# Altium schematic sheet Top_Level.SchDoc
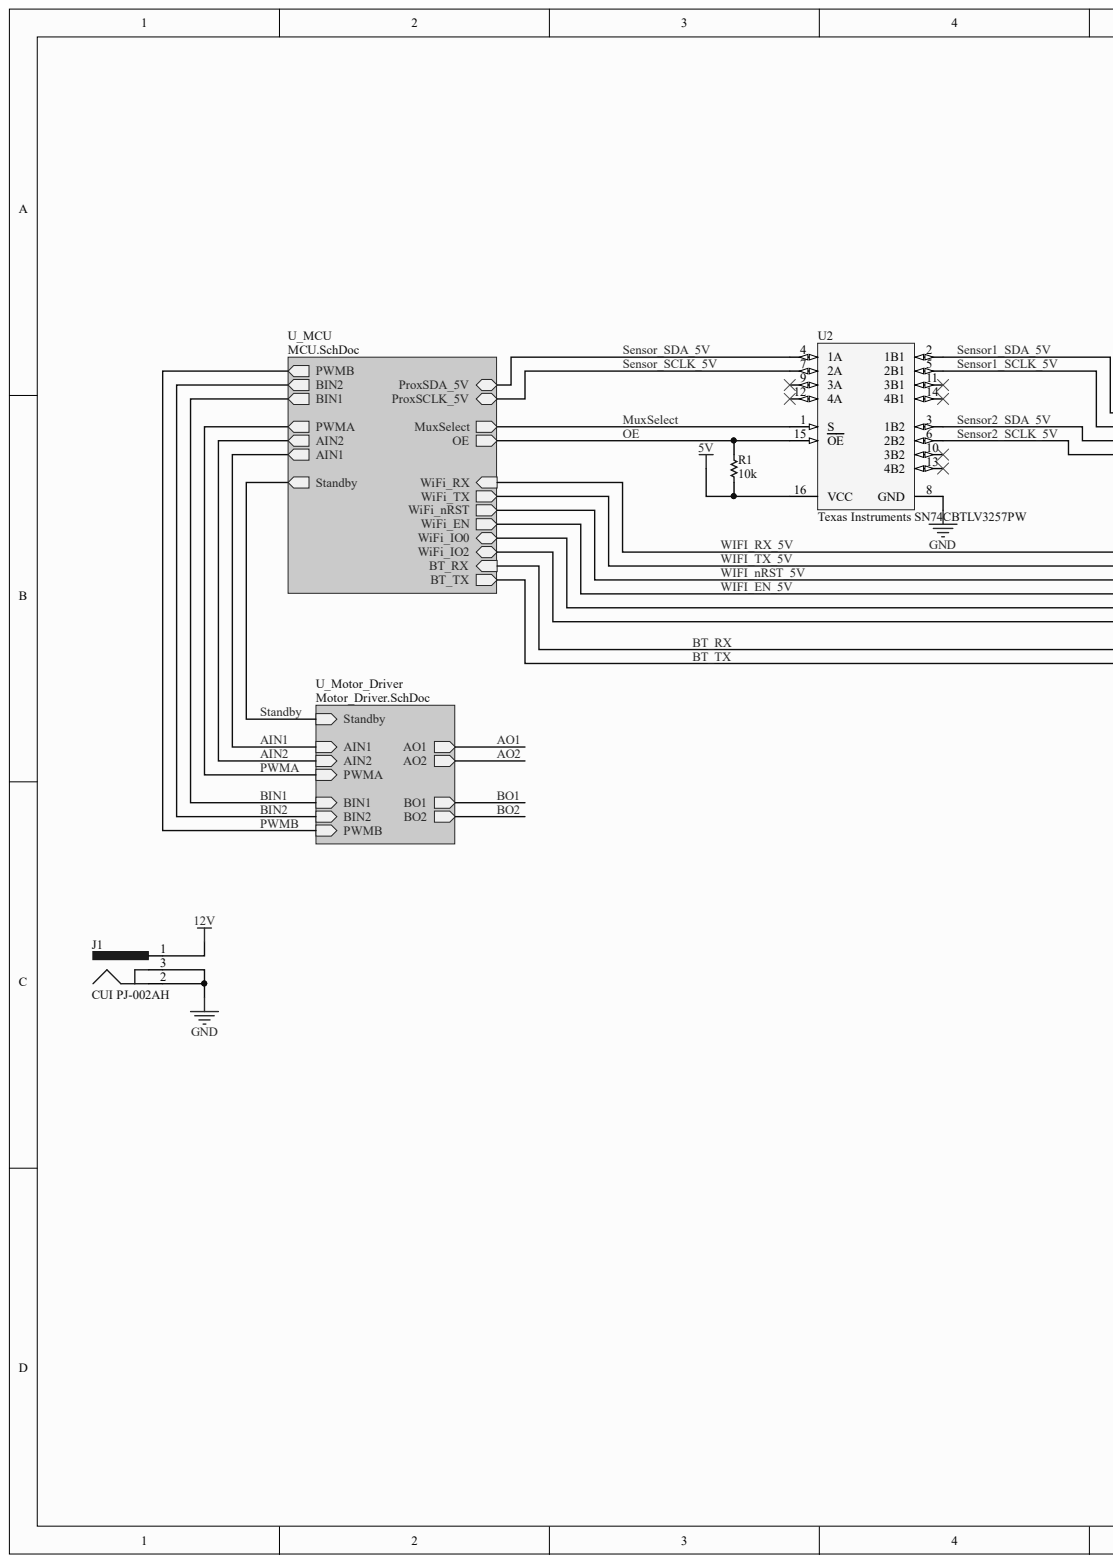
[diagram p0: Top_Level.SchDoc, left]
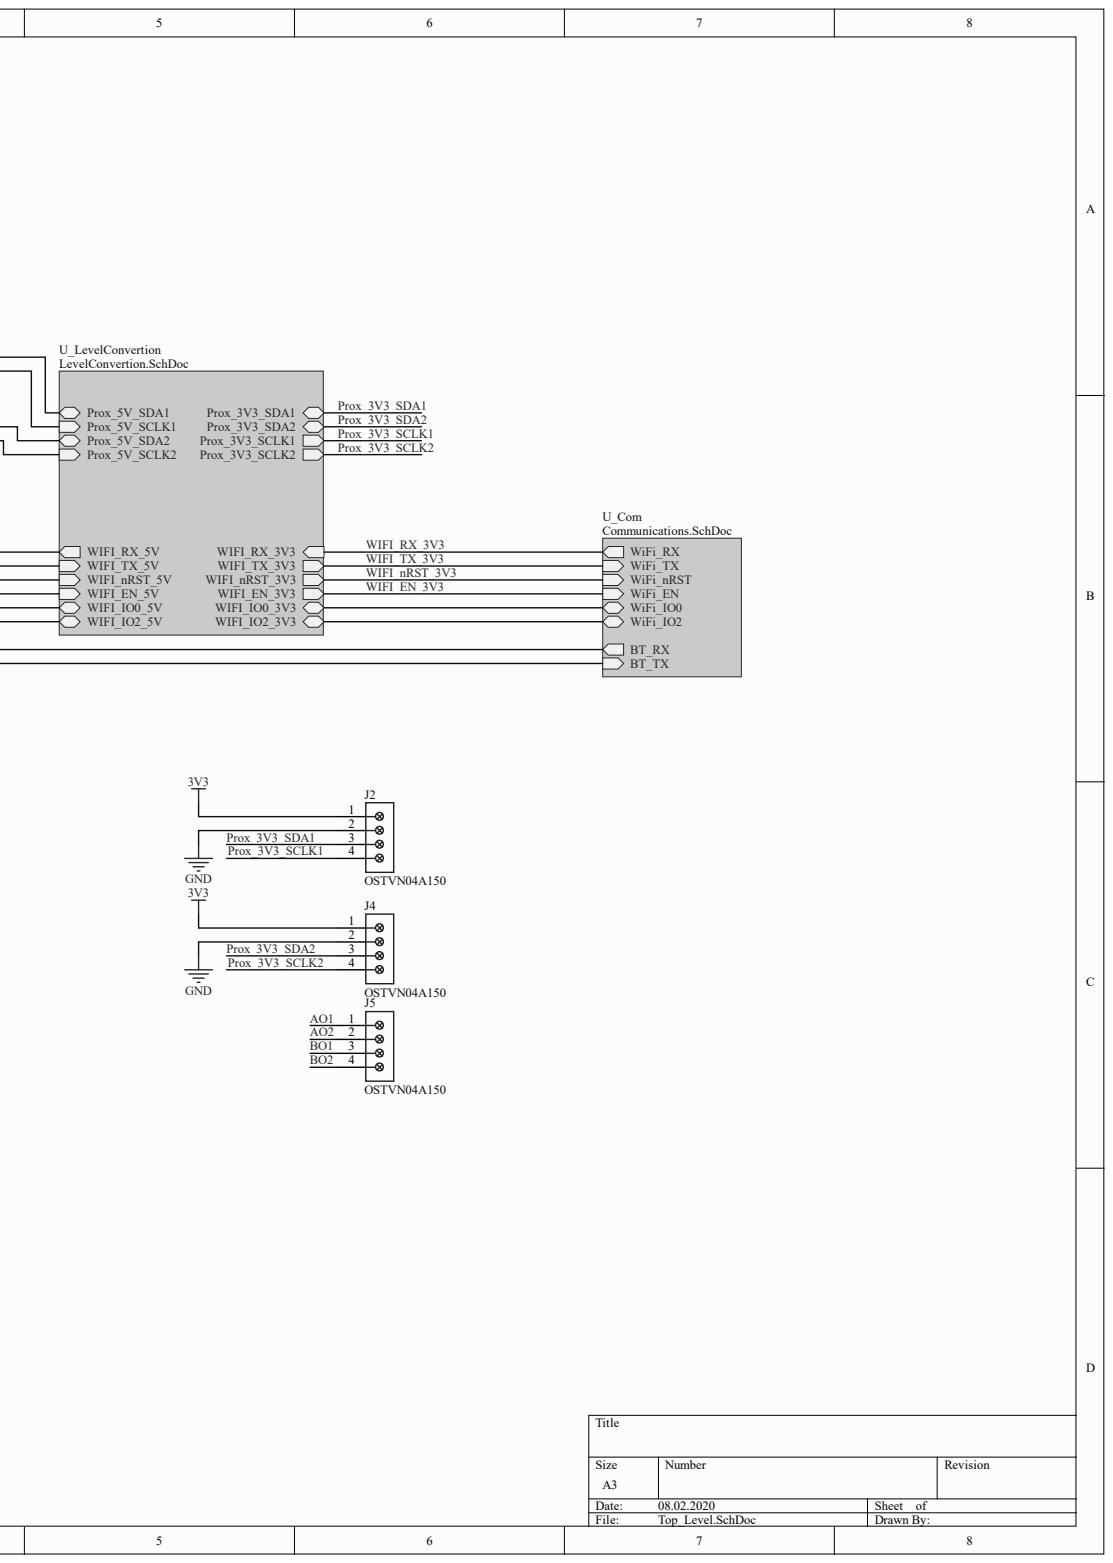
[diagram p1: Top_Level.SchDoc, right]

## Parts
J1  CUI PJ-002AH  Manufacturer Part Number: PJ-002AH  [PCB-0ty8amadexon1yj69y31-1]
J2  OSTVN04A150  [SCREW-TERMINAL-10POS-0.1]
J4  OSTVN04A150  [SCREW-TERMINAL-10POS-0.1]
J5  OSTVN04A150  [SCREW-TERMINAL-10POS-0.1]
R1  10k  [PCB-h8byfae9iaxnn3vjg0ka-1]
U2  Texas Instruments SN74CBTLV3257PW  Manufacturer Part Number: SN74CBTLV3257PW  [PCB-50fvnlmj5rlotdvbdxi1-1]

## Nets
3V3: J2.1 J4.1
5V: R1.1 U2.16(VCC)
12V: J1.1
GND: J1.2 J1.3 J2.2 J4.2 U2.8(GND)
AO1: J5.1
AO2: J5.2
BO1: J5.3
BO2: J5.4
MUXSELECT: U2.1(S)
OE: R1.2 U2.15(OE)
PROX_3V3_SCLK1: J2.4
PROX_3V3_SCLK2: J4.4
PROX_3V3_SDA1: J2.3
PROX_3V3_SDA2: J4.3
SENSOR1_SCLK_5V: U2.5(2B1)
SENSOR1_SDA_5V: U2.2(1B1)
SENSOR2_SCLK_5V: U2.6(2B2)
SENSOR2_SDA_5V: U2.3(1B2)
SENSOR_SCLK_5V: U2.7(2A)
SENSOR_SDA_5V: U2.4(1A)
NetU2_9: U2.9(3A)
NetU2_10: U2.10(3B2)
NetU2_11: U2.11(3B1)
NetU2_12: U2.12(4A)
NetU2_13: U2.13(4B2)
NetU2_14: U2.14(4B1)
AIN1:
AIN2:
BIN1:
BIN2:
BT_RX:
BT_TX:
PWMA:
PWMB:
STANDBY:
WIFI_EN_3V3:
WIFI_EN_5V:
WIFI_IO0:
WIFI_IO0_5V:
WIFI_IO2:
WIFI_IO2_5V:
WIFI_NRST_3V3:
WIFI_NRST_5V:
WIFI_RX_3V3:
WIFI_RX_5V:
WIFI_TX_3V3:
WIFI_TX_5V:

## Library data of the parts
J1 = CMP-0cf6c5f84932d331-8: 2 x 6.5 mm, 5.0 A, Horizontal, Through Hole, Dc Power Jack Connector; Contact Resistance: 30.0 mΩ (max); Color: Black; Component Kind: Standard; Library Name: CommunityVault
J2 J4 J5 = CMP-4dbe8591ef2d9279-5: Conn Terminal Block 4 POS 2.54mm Solder ST Thru-Hole 6A; Component Kind: Standard; Library Name: CommunityVault
R1 = CMP-3f5ef504e47fe099-1: ERG Series 3 W 10 kOhm ±5% ±300 ppm/°C Axial Metal Oxide Film Resistor; Manufacturer Part Number: ERG-3SJ103; Insulation Resistance: 10.0 GΩ (min); Lead-Free Status: Lead Free; Power Rating: 3.00 W; Resistance: 10.0 kΩ; Temperature Coefficient: -300 ppm/°C to 300 ppm/°C; Voltage Rating (DC): 350 V; Component Kind: Standard; Library Name: CommunityVault; Lifecycle Status: Not Listed by Manufacturer; Mounting Style: Through Hole; OctopartID: 3f5ef504e47fe099; Octopart URL: https://octopart.com/erg-3sj103-panasonic-55639623; Operating Temperature: 240 °C (max); Packaging: Bulk; REACH SVHC Compliance: No SVHC; Resistance Tolerance: ±5%; RoHS: Compliant; Size-Diameter: 5.50 mm; Size-Length: 15.0 mm
U2 = CMP-7b02b202ae27a4c5-1: 4-channel, 2: 1 analog switch with power-off protection 16-TSSOP -40 to 85; Bandwidth: 200 MHz; Lead-Free Status: Lead Free; Component Kind: Standard; Library Name: CommunityVault; Lifecycle Status: Active; Number of Pins: 16; OctopartID: 7b02b202ae27a4c5
